# Altium schematic sheet 分体式smoothie主控_底板.SchDoc(分体式smoothie主控_底板)
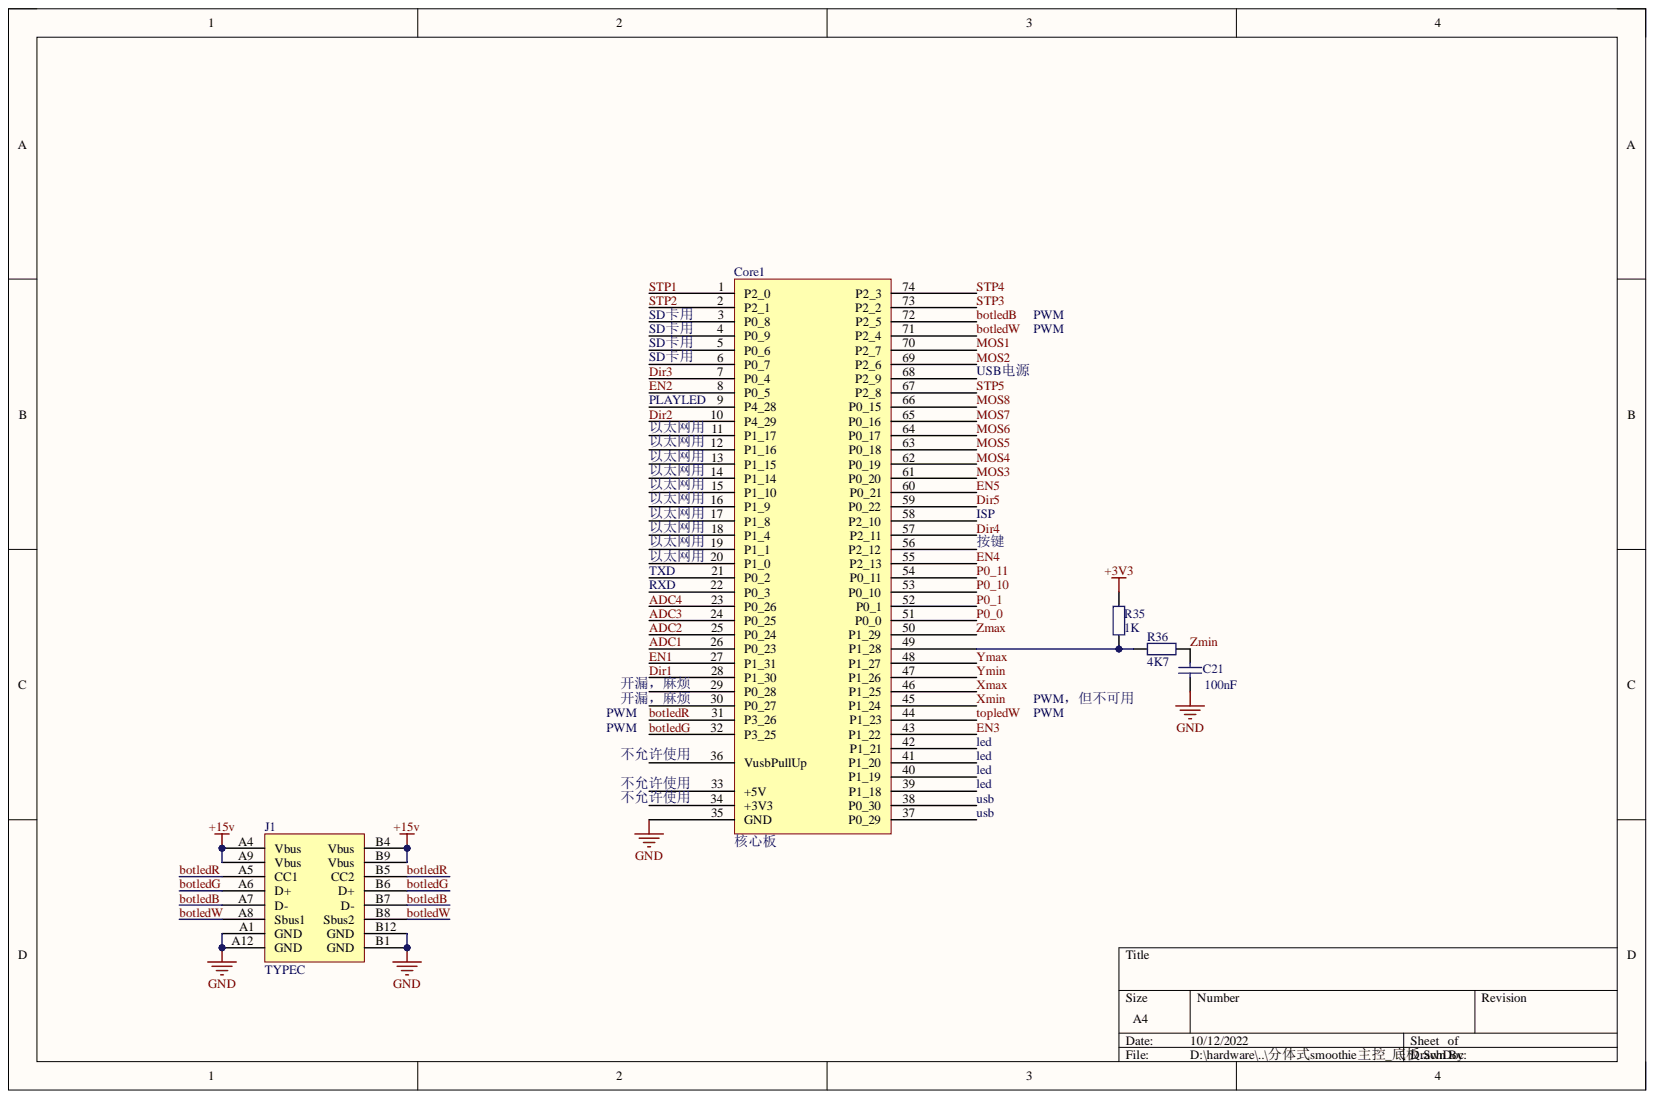
[diagram p0: 分体式smoothie主控_底板.SchDoc]

## Parts
C21  100nF  [0805c]
Core1  核心板  [LPC17XX_100pin]
J1  TYPEC  [TYPEC16P_SMT_THT]
R35  1K  [0805r]
R36  4K7  [0805r]

## Nets
+3V3: R35.1
+15v: J1.A4(Vbus) J1.A9(Vbus) J1.B4(Vbus) J1.B9(Vbus)
GND: C21.1 Core1.35(GND) J1.A1(GND) J1.A12(GND) J1.B1(GND) J1.B12(GND)
ADC1: Core1.26(P0_23)
ADC2: Core1.25(P0_24)
ADC3: Core1.24(P0_25)
ADC4: Core1.23(P0_26)
botledB: Core1.72(P2_5) J1.A7(D-) J1.B7(D-)
botledG: Core1.32(P3_25) J1.A6(D+) J1.B6(D+)
botledR: Core1.31(P3_26) J1.A5(CC1) J1.B5(CC2)
botledW: Core1.71(P2_4) J1.A8(Sbus1) J1.B8(Sbus2)
Dir1: Core1.28(P1_30)
Dir2: Core1.10(P4_29)
Dir3: Core1.7(P0_4)
Dir4: Core1.57(P2_11)
Dir5: Core1.59(P0_22)
EN1: Core1.27(P1_31)
EN2: Core1.8(P0_5)
EN3: Core1.43(P1_22)
EN4: Core1.55(P2_13)
EN5: Core1.60(P0_21)
MOS1: Core1.70(P2_7)
MOS2: Core1.69(P2_6)
MOS3: Core1.61(P0_20)
MOS4: Core1.62(P0_19)
MOS5: Core1.63(P0_18)
MOS6: Core1.64(P0_17)
MOS7: Core1.65(P0_16)
MOS8: Core1.66(P0_15)
P0_0: Core1.51(P0_0)
P0_1: Core1.52(P0_1)
P0_10: Core1.53(P0_10)
P0_11: Core1.54(P0_11)
STP1: Core1.1(P2_0)
STP2: Core1.2(P2_1)
STP3: Core1.73(P2_2)
STP4: Core1.74(P2_3)
STP5: Core1.67(P2_8)
topledW: Core1.44(P1_23)
Xmax: Core1.46(P1_25)
Xmin: Core1.45(P1_24)
Ymax: Core1.48(P1_27)
Ymin: Core1.47(P1_26)
Zmax: Core1.50(P1_29)
Zmin: C21.2 R36.2
NetCore1_49: Core1.49(P1_28) R35.2 R36.1

Not connected: Core1 3(P0_8) 4(P0_9) 5(P0_6) 6(P0_7) 9(P4_28) 11(P1_17) 12(P1_16) 13(P1_15) 14(P1_14) 15(P1_10) 16(P1_9) 17(P1_8) 18(P1_4) 19(P1_1) 20(P1_0) 21(P0_2) 22(P0_3) 29(P0_28) 30(P0_27) 33(+5V) 34(+3V3) 36(VusbPullUp) 37(P0_29) 38(P0_30) 39(P1_18) 40(P1_19) 41(P1_20) 42(P1_21) 56(P2_12) 58(P2_10) 68(P2_9)

## Library data of the parts
C21 = Cap: 无极性定值电容; Component Kind: Standard; LatestRevisionDate: 17-Jul-2002; LatestRevisionNote: Re-released for DXP Platform.; Library Name: 常用.SchLib; PackageDescription: Radial Cap, Thru-Hole; 2 Leads; 0.3 in Pin Spacing; PackageReference: RAD-0.3; Published: 8-Jun-2000; Signal Integrity: Cap; Simulation: CAP; Publisher: Altium Limited
Core1 = LPC17XX_100PIN: 100脚LPC17xx系列核心板; Component Kind: Standard; Library Name: 开发板核心板.SchLib
J1 = TypeC_16PIN: Component Kind: Standard; Library Name: 接插头.SchLib
R35 R36 = Res2: 定值电阻; Component Kind: Standard; LatestRevisionDate: 17-Jul-2002; LatestRevisionNote: Re-released for DXP Platform.; Library Name: 常用.SchLib; PackageDescription: Axial Device, Thru-Hole; 2 Leads; 0.4 in Pin Spacing; PackageReference: AXIAL-0.4; Published: 8-Jun-2000; Signal Integrity: Res; Simulation: RESISTOR; Publisher: Altium Limited
